# Altium schematic sheet PicoZed.SchDoc
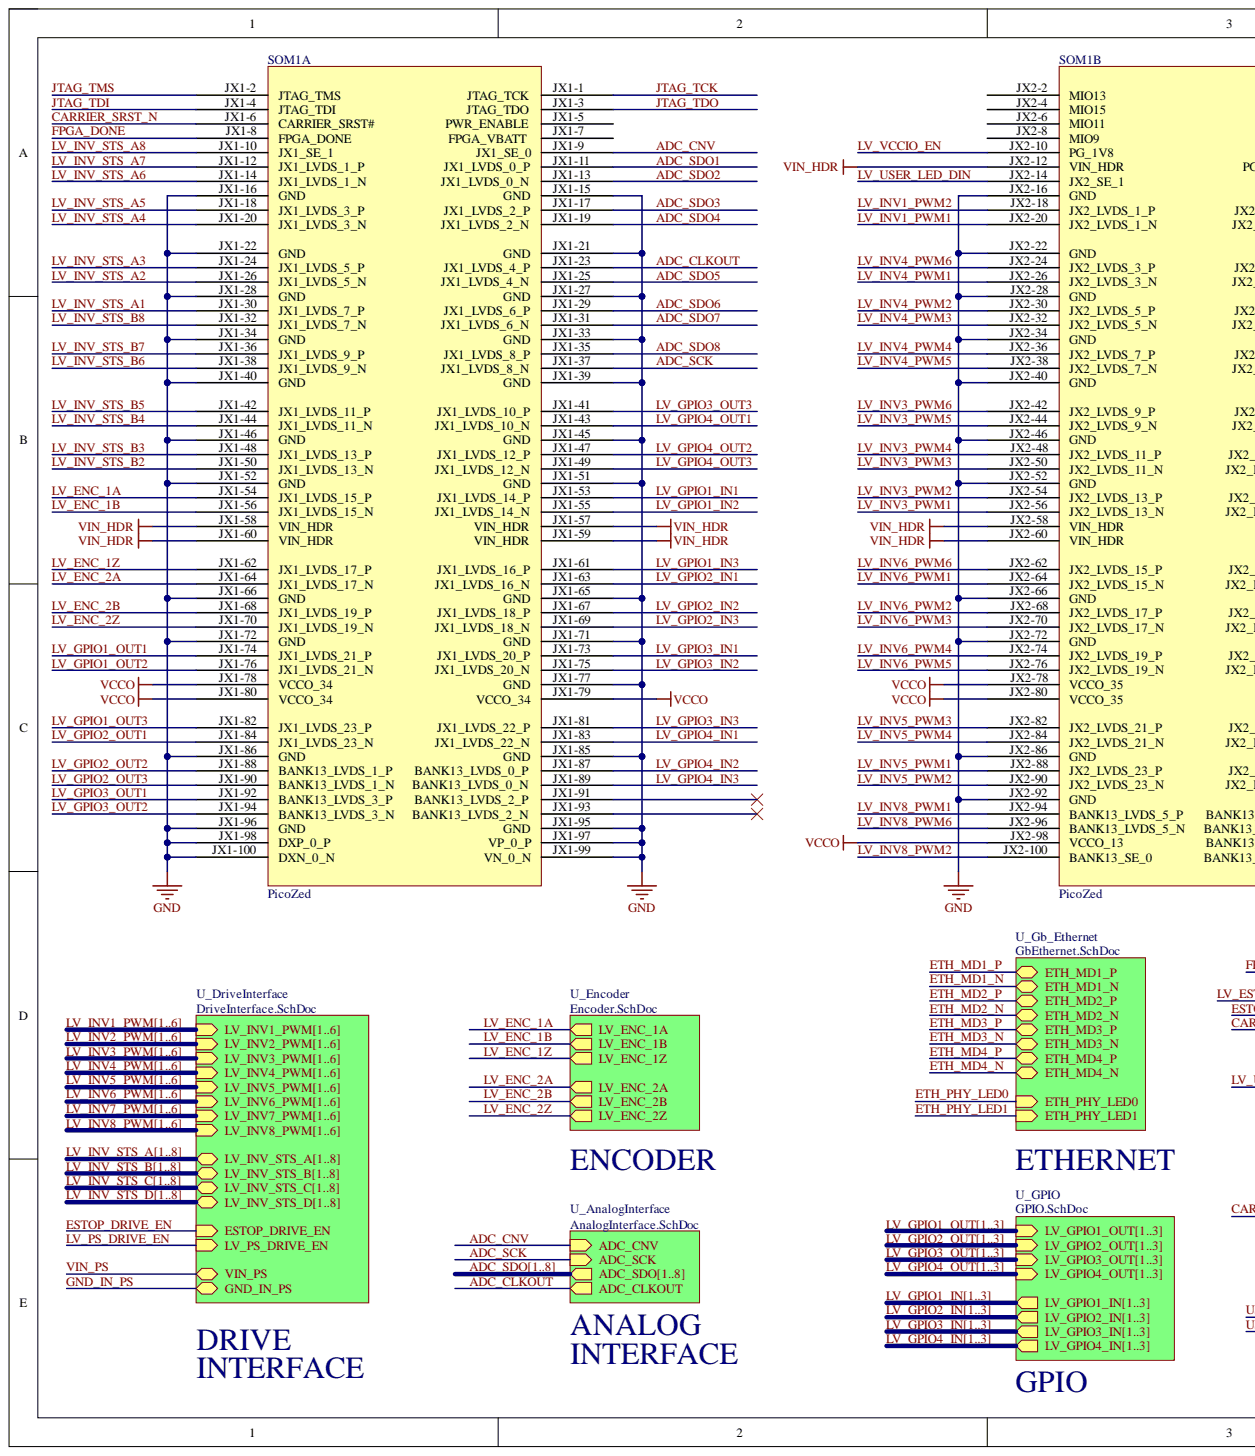
[diagram p0: PicoZed.SchDoc, left]
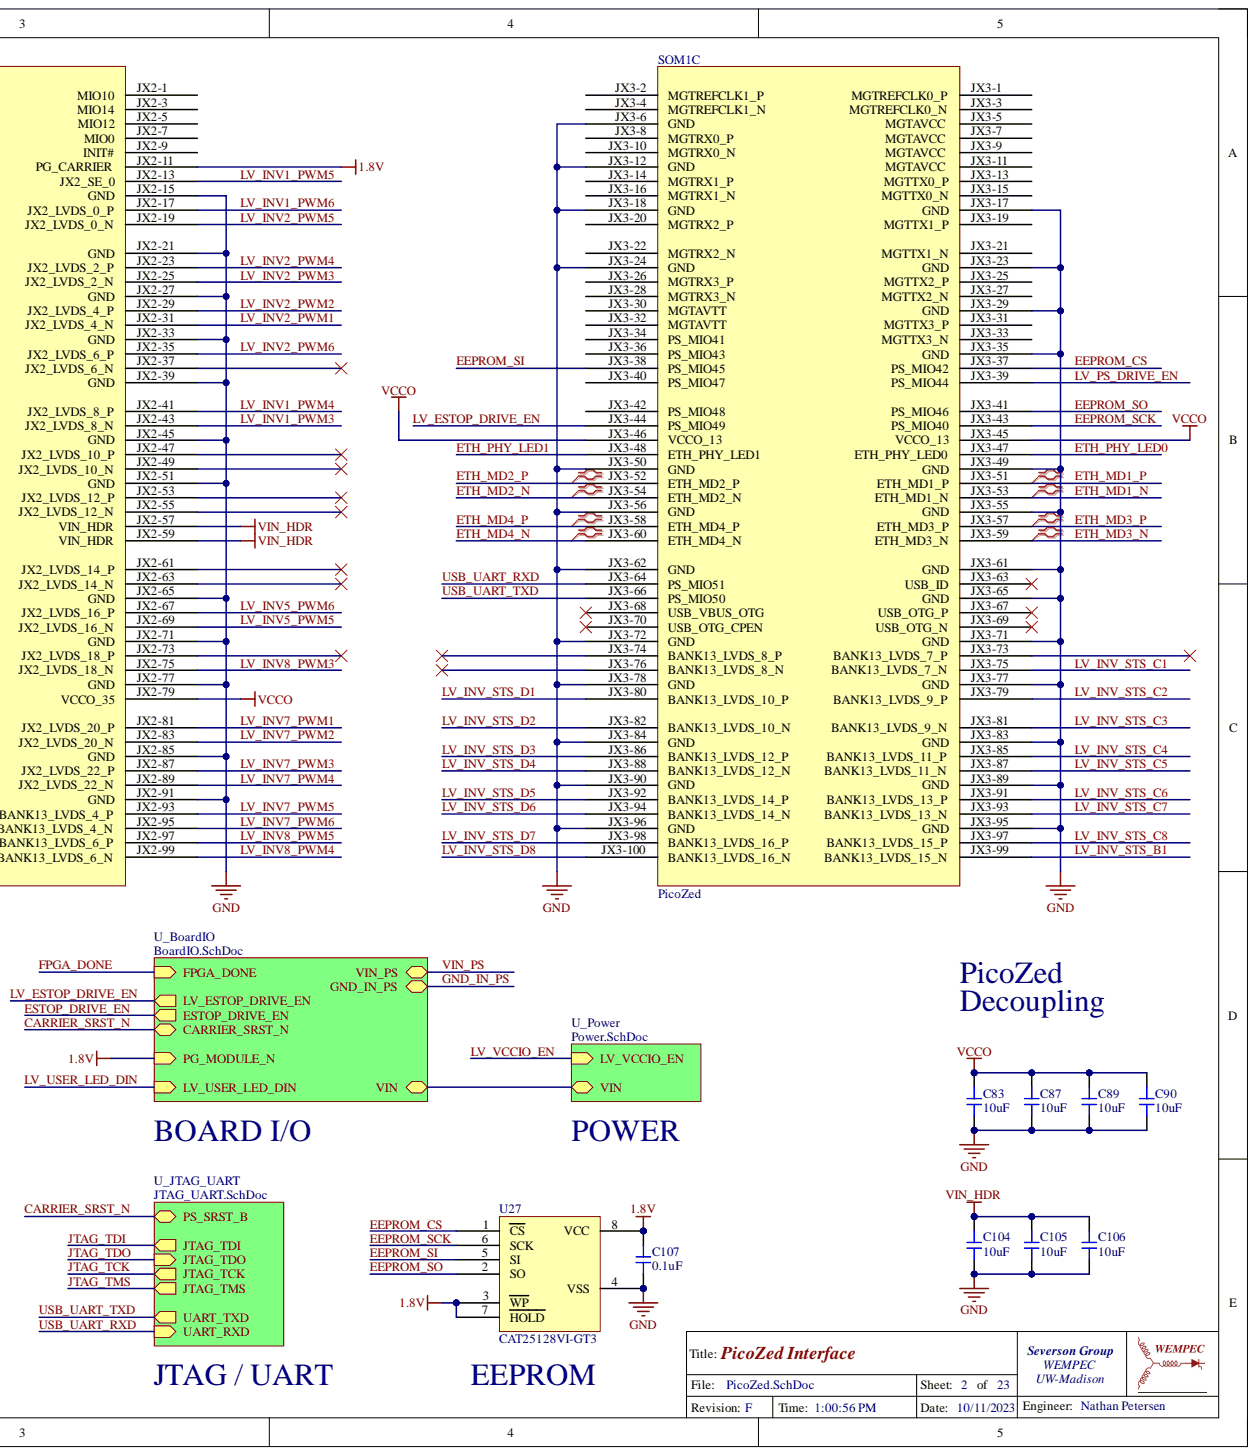
[diagram p1: PicoZed.SchDoc, right]

## Parts
C83  value 10uF  [0603-CAP]  (hidden comment: Capacitor)
C87  value 10uF  [0603-CAP]  (hidden comment: Capacitor)
C89  value 10uF  [0603-CAP]  (hidden comment: Capacitor)
C90  value 10uF  [0603-CAP]  (hidden comment: Capacitor)
C104  value 10uF  [0603-CAP]  (hidden comment: Capacitor)
C105  value 10uF  [0603-CAP]  (hidden comment: Capacitor)
C106  value 10uF  [0603-CAP]  (hidden comment: Capacitor)
C107  value 0.1uF  [0603-CAP]  (hidden comment: Capacitor)
SOM1  PicoZed  [PicoZedSOM]  (no BOM)  (parts A,B,C)
U27  CAT25128VI-GT3  [SOIC127P600X175-8N]

## Nets
1.8V: C107.2 SOM1.JX2-11(PG_CARRIER) U27.3(WP) U27.7(HOLD) U27.8(VCC)
GND: C83.1 C87.1 C89.1 C90.1 C104.1 C105.1 C106.1 C107.1 SOM1.JX1-15(GND) SOM1.JX1-16(GND) SOM1.JX1-21(GND) SOM1.JX1-22(GND) SOM1.JX1-27(GND) SOM1.JX1-28(GND) SOM1.JX1-33(GND) SOM1.JX1-34(GND) SOM1.JX1-39(GND) SOM1.JX1-40(GND) SOM1.JX1-45(GND) SOM1.JX1-46(GND) SOM1.JX1-51(GND) SOM1.JX1-52(GND) SOM1.JX1-65(GND) SOM1.JX1-66(GND) SOM1.JX1-71(GND) SOM1.JX1-72(GND) SOM1.JX1-77(GND) SOM1.JX1-85(GND) SOM1.JX1-86(GND) SOM1.JX1-95(GND) SOM1.JX1-96(GND) SOM1.JX1-97(VP_0_P) SOM1.JX1-98(DXP_0_P) SOM1.JX1-99(VN_0_N) SOM1.JX1-100(DXN_0_N) SOM1.JX2-15(GND) SOM1.JX2-16(GND) SOM1.JX2-21(GND) SOM1.JX2-22(GND) SOM1.JX2-27(GND) SOM1.JX2-28(GND) SOM1.JX2-33(GND) SOM1.JX2-34(GND) SOM1.JX2-39(GND) SOM1.JX2-40(GND) SOM1.JX2-45(GND) SOM1.JX2-46(GND) SOM1.JX2-51(GND) SOM1.JX2-52(GND) SOM1.JX2-65(GND) SOM1.JX2-66(GND) SOM1.JX2-71(GND) SOM1.JX2-72(GND) SOM1.JX2-77(GND) SOM1.JX2-85(GND) SOM1.JX2-86(GND) SOM1.JX2-91(GND) SOM1.JX2-92(GND) SOM1.JX3-6(GND) SOM1.JX3-12(GND) SOM1.JX3-17(GND) SOM1.JX3-18(GND) SOM1.JX3-23(GND) SOM1.JX3-24(GND) SOM1.JX3-29(GND) SOM1.JX3-35(GND) SOM1.JX3-49(GND) SOM1.JX3-50(GND) SOM1.JX3-55(GND) SOM1.JX3-56(GND) SOM1.JX3-61(GND) SOM1.JX3-62(GND) SOM1.JX3-65(GND) SOM1.JX3-71(GND) SOM1.JX3-72(GND) SOM1.JX3-77(GND) SOM1.JX3-78(GND) SOM1.JX3-83(GND) SOM1.JX3-84(GND) SOM1.JX3-89(GND) SOM1.JX3-90(GND) SOM1.JX3-95(GND) SOM1.JX3-96(GND) U27.4(VSS)
VCCO: C83.2 C87.2 C89.2 C90.2 SOM1.JX1-78(VCCO_34) SOM1.JX1-79(VCCO_34) SOM1.JX1-80(VCCO_34) SOM1.JX2-78(VCCO_35) SOM1.JX2-79(VCCO_35) SOM1.JX2-80(VCCO_35) SOM1.JX2-98(VCCO_13) SOM1.JX3-45(VCCO_13) SOM1.JX3-46(VCCO_13)
VIN_HDR: C104.2 C105.2 C106.2 SOM1.JX1-57(VIN_HDR) SOM1.JX1-58(VIN_HDR) SOM1.JX1-59(VIN_HDR) SOM1.JX1-60(VIN_HDR) SOM1.JX2-12(VIN_HDR) SOM1.JX2-57(VIN_HDR) SOM1.JX2-58(VIN_HDR) SOM1.JX2-59(VIN_HDR) SOM1.JX2-60(VIN_HDR)
ADC_CLKOUT: SOM1.JX1-23(JX1_LVDS_4_P)
ADC_CNV: SOM1.JX1-9(JX1_SE_0)
ADC_SCK: SOM1.JX1-37(JX1_LVDS_8_N)
ADC_SDO1: SOM1.JX1-11(JX1_LVDS_0_P)  [also labelled ADC_SDO]
ADC_SDO2: SOM1.JX1-13(JX1_LVDS_0_N)  [also labelled ADC_SDO]
ADC_SDO3: SOM1.JX1-17(JX1_LVDS_2_P)  [also labelled ADC_SDO]
ADC_SDO4: SOM1.JX1-19(JX1_LVDS_2_N)  [also labelled ADC_SDO]
ADC_SDO5: SOM1.JX1-25(JX1_LVDS_4_N)  [also labelled ADC_SDO]
ADC_SDO6: SOM1.JX1-29(JX1_LVDS_6_P)  [also labelled ADC_SDO]
ADC_SDO7: SOM1.JX1-31(JX1_LVDS_6_N)  [also labelled ADC_SDO]
ADC_SDO8: SOM1.JX1-35(JX1_LVDS_8_P)  [also labelled ADC_SDO]
CARRIER_SRST_N: SOM1.JX1-6(CARRIER_SRST#)
EEPROM_CS: SOM1.JX3-37(PS_MIO42) U27.1(CS)
EEPROM_SCK: SOM1.JX3-43(PS_MIO40) U27.6(SCK)
EEPROM_SI: SOM1.JX3-38(PS_MIO45) U27.5(SI)
EEPROM_SO: SOM1.JX3-41(PS_MIO46) U27.2(SO)
ETH_MD1_N: SOM1.JX3-53(ETH_MD1_N)
ETH_MD1_P: SOM1.JX3-51(ETH_MD1_P)
ETH_MD2_N: SOM1.JX3-54(ETH_MD2_N)
ETH_MD2_P: SOM1.JX3-52(ETH_MD2_P)
ETH_MD3_N: SOM1.JX3-59(ETH_MD3_N)
ETH_MD3_P: SOM1.JX3-57(ETH_MD3_P)
ETH_MD4_N: SOM1.JX3-60(ETH_MD4_N)
ETH_MD4_P: SOM1.JX3-58(ETH_MD4_P)
ETH_PHY_LED0: SOM1.JX3-47(ETH_PHY_LED0)
ETH_PHY_LED1: SOM1.JX3-48(ETH_PHY_LED1)
FPGA_DONE: SOM1.JX1-8(FPGA_DONE)
JTAG_TCK: SOM1.JX1-1(JTAG_TCK)
JTAG_TDI: SOM1.JX1-4(JTAG_TDI)
JTAG_TDO: SOM1.JX1-3(JTAG_TDO)
JTAG_TMS: SOM1.JX1-2(JTAG_TMS)
LV_ENC_1A: SOM1.JX1-54(JX1_LVDS_15_P)
LV_ENC_1B: SOM1.JX1-56(JX1_LVDS_15_N)
LV_ENC_1Z: SOM1.JX1-62(JX1_LVDS_17_P)
LV_ENC_2A: SOM1.JX1-64(JX1_LVDS_17_N)
LV_ENC_2B: SOM1.JX1-68(JX1_LVDS_19_P)
LV_ENC_2Z: SOM1.JX1-70(JX1_LVDS_19_N)
LV_ESTOP_DRIVE_EN: SOM1.JX3-44(PS_MIO49)
LV_GPIO1_IN1: SOM1.JX1-53(JX1_LVDS_14_P)  [also labelled LV_GPIO1_IN]
LV_GPIO1_IN2: SOM1.JX1-55(JX1_LVDS_14_N)  [also labelled LV_GPIO1_IN]
LV_GPIO1_IN3: SOM1.JX1-61(JX1_LVDS_16_P)  [also labelled LV_GPIO1_IN]
LV_GPIO1_OUT1: SOM1.JX1-74(JX1_LVDS_21_P)  [also labelled LV_GPIO1_OUT]
LV_GPIO1_OUT2: SOM1.JX1-76(JX1_LVDS_21_N)  [also labelled LV_GPIO1_OUT]
LV_GPIO1_OUT3: SOM1.JX1-82(JX1_LVDS_23_P)  [also labelled LV_GPIO1_OUT]
LV_GPIO2_IN1: SOM1.JX1-63(JX1_LVDS_16_N)  [also labelled LV_GPIO2_IN]
LV_GPIO2_IN2: SOM1.JX1-67(JX1_LVDS_18_P)  [also labelled LV_GPIO2_IN]
LV_GPIO2_IN3: SOM1.JX1-69(JX1_LVDS_18_N)  [also labelled LV_GPIO2_IN]
LV_GPIO2_OUT1: SOM1.JX1-84(JX1_LVDS_23_N)  [also labelled LV_GPIO2_OUT]
LV_GPIO2_OUT2: SOM1.JX1-88(BANK13_LVDS_1_P)  [also labelled LV_GPIO2_OUT]
LV_GPIO2_OUT3: SOM1.JX1-90(BANK13_LVDS_1_N)  [also labelled LV_GPIO2_OUT]
LV_GPIO3_IN1: SOM1.JX1-73(JX1_LVDS_20_P)  [also labelled LV_GPIO3_IN]
LV_GPIO3_IN2: SOM1.JX1-75(JX1_LVDS_20_N)  [also labelled LV_GPIO3_IN]
LV_GPIO3_IN3: SOM1.JX1-81(JX1_LVDS_22_P)  [also labelled LV_GPIO3_IN]
LV_GPIO3_OUT1: SOM1.JX1-92(BANK13_LVDS_3_P)  [also labelled LV_GPIO3_OUT]
LV_GPIO3_OUT2: SOM1.JX1-94(BANK13_LVDS_3_N)  [also labelled LV_GPIO3_OUT]
LV_GPIO3_OUT3: SOM1.JX1-41(JX1_LVDS_10_P)  [also labelled LV_GPIO3_OUT]
LV_GPIO4_IN1: SOM1.JX1-83(JX1_LVDS_22_N)  [also labelled LV_GPIO4_IN]
LV_GPIO4_IN2: SOM1.JX1-87(BANK13_LVDS_0_P)  [also labelled LV_GPIO4_IN]
LV_GPIO4_IN3: SOM1.JX1-89(BANK13_LVDS_0_N)  [also labelled LV_GPIO4_IN]
LV_GPIO4_OUT1: SOM1.JX1-43(JX1_LVDS_10_N)  [also labelled LV_GPIO4_OUT]
LV_GPIO4_OUT2: SOM1.JX1-47(JX1_LVDS_12_P)  [also labelled LV_GPIO4_OUT]
LV_GPIO4_OUT3: SOM1.JX1-49(JX1_LVDS_12_N)  [also labelled LV_GPIO4_OUT]
LV_INV1_PWM1: SOM1.JX2-20(JX2_LVDS_1_N)  [also labelled LV_INV1_PWM]
LV_INV1_PWM2: SOM1.JX2-18(JX2_LVDS_1_P)  [also labelled LV_INV1_PWM]
LV_INV1_PWM3: SOM1.JX2-43(JX2_LVDS_8_N)  [also labelled LV_INV1_PWM]
LV_INV1_PWM4: SOM1.JX2-41(JX2_LVDS_8_P)  [also labelled LV_INV1_PWM]
LV_INV1_PWM5: SOM1.JX2-13(JX2_SE_0)  [also labelled LV_INV1_PWM]
LV_INV1_PWM6: SOM1.JX2-17(JX2_LVDS_0_P)  [also labelled LV_INV1_PWM]
LV_INV2_PWM1: SOM1.JX2-31(JX2_LVDS_4_N)  [also labelled LV_INV2_PWM]
LV_INV2_PWM2: SOM1.JX2-29(JX2_LVDS_4_P)  [also labelled LV_INV2_PWM]
LV_INV2_PWM3: SOM1.JX2-25(JX2_LVDS_2_N)  [also labelled LV_INV2_PWM]
LV_INV2_PWM4: SOM1.JX2-23(JX2_LVDS_2_P)  [also labelled LV_INV2_PWM]
LV_INV2_PWM5: SOM1.JX2-19(JX2_LVDS_0_N)  [also labelled LV_INV2_PWM]
LV_INV2_PWM6: SOM1.JX2-35(JX2_LVDS_6_P)  [also labelled LV_INV2_PWM]
LV_INV3_PWM1: SOM1.JX2-56(JX2_LVDS_13_N)  [also labelled LV_INV3_PWM]
LV_INV3_PWM2: SOM1.JX2-54(JX2_LVDS_13_P)  [also labelled LV_INV3_PWM]
LV_INV3_PWM3: SOM1.JX2-50(JX2_LVDS_11_N)  [also labelled LV_INV3_PWM]
LV_INV3_PWM4: SOM1.JX2-48(JX2_LVDS_11_P)  [also labelled LV_INV3_PWM]
LV_INV3_PWM5: SOM1.JX2-44(JX2_LVDS_9_N)  [also labelled LV_INV3_PWM]
LV_INV3_PWM6: SOM1.JX2-42(JX2_LVDS_9_P)  [also labelled LV_INV3_PWM]
LV_INV4_PWM1: SOM1.JX2-26(JX2_LVDS_3_N)  [also labelled LV_INV4_PWM]
LV_INV4_PWM2: SOM1.JX2-30(JX2_LVDS_5_P)  [also labelled LV_INV4_PWM]
LV_INV4_PWM3: SOM1.JX2-32(JX2_LVDS_5_N)  [also labelled LV_INV4_PWM]
LV_INV4_PWM4: SOM1.JX2-36(JX2_LVDS_7_P)  [also labelled LV_INV4_PWM]
LV_INV4_PWM5: SOM1.JX2-38(JX2_LVDS_7_N)  [also labelled LV_INV4_PWM]
LV_INV4_PWM6: SOM1.JX2-24(JX2_LVDS_3_P)  [also labelled LV_INV4_PWM]
LV_INV5_PWM1: SOM1.JX2-88(JX2_LVDS_23_P)  [also labelled LV_INV5_PWM]
LV_INV5_PWM2: SOM1.JX2-90(JX2_LVDS_23_N)  [also labelled LV_INV5_PWM]
LV_INV5_PWM3: SOM1.JX2-82(JX2_LVDS_21_P)  [also labelled LV_INV5_PWM]
LV_INV5_PWM4: SOM1.JX2-84(JX2_LVDS_21_N)  [also labelled LV_INV5_PWM]
LV_INV5_PWM5: SOM1.JX2-69(JX2_LVDS_16_N)  [also labelled LV_INV5_PWM]
LV_INV5_PWM6: SOM1.JX2-67(JX2_LVDS_16_P)  [also labelled LV_INV5_PWM]
LV_INV6_PWM1: SOM1.JX2-64(JX2_LVDS_15_N)  [also labelled LV_INV6_PWM]
LV_INV6_PWM2: SOM1.JX2-68(JX2_LVDS_17_P)  [also labelled LV_INV6_PWM]
LV_INV6_PWM3: SOM1.JX2-70(JX2_LVDS_17_N)  [also labelled LV_INV6_PWM]
LV_INV6_PWM4: SOM1.JX2-74(JX2_LVDS_19_P)  [also labelled LV_INV6_PWM]
LV_INV6_PWM5: SOM1.JX2-76(JX2_LVDS_19_N)  [also labelled LV_INV6_PWM]
LV_INV6_PWM6: SOM1.JX2-62(JX2_LVDS_15_P)  [also labelled LV_INV6_PWM]
LV_INV7_PWM1: SOM1.JX2-81(JX2_LVDS_20_P)  [also labelled LV_INV7_PWM]
LV_INV7_PWM2: SOM1.JX2-83(JX2_LVDS_20_N)  [also labelled LV_INV7_PWM]
LV_INV7_PWM3: SOM1.JX2-87(JX2_LVDS_22_P)  [also labelled LV_INV7_PWM]
LV_INV7_PWM4: SOM1.JX2-89(JX2_LVDS_22_N)  [also labelled LV_INV7_PWM]
LV_INV7_PWM5: SOM1.JX2-93(BANK13_LVDS_4_P)  [also labelled LV_INV7_PWM]
LV_INV7_PWM6: SOM1.JX2-95(BANK13_LVDS_4_N)  [also labelled LV_INV7_PWM]
LV_INV8_PWM1: SOM1.JX2-94(BANK13_LVDS_5_P)  [also labelled LV_INV8_PWM]
LV_INV8_PWM2: SOM1.JX2-100(BANK13_SE_0)  [also labelled LV_INV8_PWM]
LV_INV8_PWM3: SOM1.JX2-75(JX2_LVDS_18_N)  [also labelled LV_INV8_PWM]
LV_INV8_PWM4: SOM1.JX2-99(BANK13_LVDS_6_N)  [also labelled LV_INV8_PWM]
LV_INV8_PWM5: SOM1.JX2-97(BANK13_LVDS_6_P)  [also labelled LV_INV8_PWM]
LV_INV8_PWM6: SOM1.JX2-96(BANK13_LVDS_5_N)  [also labelled LV_INV8_PWM]
LV_INV_STS_A1: SOM1.JX1-30(JX1_LVDS_7_P)  [also labelled LV_INV_STS_A]
LV_INV_STS_A2: SOM1.JX1-26(JX1_LVDS_5_N)  [also labelled LV_INV_STS_A]
LV_INV_STS_A3: SOM1.JX1-24(JX1_LVDS_5_P)  [also labelled LV_INV_STS_A]
LV_INV_STS_A4: SOM1.JX1-20(JX1_LVDS_3_N)  [also labelled LV_INV_STS_A]
LV_INV_STS_A5: SOM1.JX1-18(JX1_LVDS_3_P)  [also labelled LV_INV_STS_A]
LV_INV_STS_A6: SOM1.JX1-14(JX1_LVDS_1_N)  [also labelled LV_INV_STS_A]
LV_INV_STS_A7: SOM1.JX1-12(JX1_LVDS_1_P)  [also labelled LV_INV_STS_A]
LV_INV_STS_A8: SOM1.JX1-10(JX1_SE_1)  [also labelled LV_INV_STS_A]
LV_INV_STS_B1: SOM1.JX3-99(BANK13_LVDS_15_N)  [also labelled LV_INV_STS_B]
LV_INV_STS_B2: SOM1.JX1-50(JX1_LVDS_13_N)  [also labelled LV_INV_STS_B]
LV_INV_STS_B3: SOM1.JX1-48(JX1_LVDS_13_P)  [also labelled LV_INV_STS_B]
LV_INV_STS_B4: SOM1.JX1-44(JX1_LVDS_11_N)  [also labelled LV_INV_STS_B]
LV_INV_STS_B5: SOM1.JX1-42(JX1_LVDS_11_P)  [also labelled LV_INV_STS_B]
LV_INV_STS_B6: SOM1.JX1-38(JX1_LVDS_9_N)  [also labelled LV_INV_STS_B]
LV_INV_STS_B7: SOM1.JX1-36(JX1_LVDS_9_P)  [also labelled LV_INV_STS_B]
LV_INV_STS_B8: SOM1.JX1-32(JX1_LVDS_7_N)  [also labelled LV_INV_STS_B]
LV_INV_STS_C1: SOM1.JX3-75(BANK13_LVDS_7_N)  [also labelled LV_INV_STS_C]
LV_INV_STS_C2: SOM1.JX3-79(BANK13_LVDS_9_P)  [also labelled LV_INV_STS_C]
LV_INV_STS_C3: SOM1.JX3-81(BANK13_LVDS_9_N)  [also labelled LV_INV_STS_C]
LV_INV_STS_C4: SOM1.JX3-85(BANK13_LVDS_11_P)  [also labelled LV_INV_STS_C]
LV_INV_STS_C5: SOM1.JX3-87(BANK13_LVDS_11_N)  [also labelled LV_INV_STS_C]
LV_INV_STS_C6: SOM1.JX3-91(BANK13_LVDS_13_P)  [also labelled LV_INV_STS_C]
LV_INV_STS_C7: SOM1.JX3-93(BANK13_LVDS_13_N)  [also labelled LV_INV_STS_C]
LV_INV_STS_C8: SOM1.JX3-97(BANK13_LVDS_15_P)  [also labelled LV_INV_STS_C]
LV_INV_STS_D1: SOM1.JX3-80(BANK13_LVDS_10_P)  [also labelled LV_INV_STS_D]
LV_INV_STS_D2: SOM1.JX3-82(BANK13_LVDS_10_N)  [also labelled LV_INV_STS_D]
LV_INV_STS_D3: SOM1.JX3-86(BANK13_LVDS_12_P)  [also labelled LV_INV_STS_D]
LV_INV_STS_D4: SOM1.JX3-88(BANK13_LVDS_12_N)  [also labelled LV_INV_STS_D]
LV_INV_STS_D5: SOM1.JX3-92(BANK13_LVDS_14_P)  [also labelled LV_INV_STS_D]
LV_INV_STS_D6: SOM1.JX3-94(BANK13_LVDS_14_N)  [also labelled LV_INV_STS_D]
LV_INV_STS_D7: SOM1.JX3-98(BANK13_LVDS_16_P)  [also labelled LV_INV_STS_D]
LV_INV_STS_D8: SOM1.JX3-100(BANK13_LVDS_16_N)  [also labelled LV_INV_STS_D]
LV_PS_DRIVE_EN: SOM1.JX3-39(PS_MIO44)
LV_USER_LED_DIN: SOM1.JX2-14(JX2_SE_1)
LV_VCCIO_EN: SOM1.JX2-10(PG_1V8)
USB_UART_RXD: SOM1.JX3-64(PS_MIO51)
USB_UART_TXD: SOM1.JX3-66(PS_MIO50)
NetSOM1_JX1-5: SOM1.JX1-5(PWR_ENABLE)
NetSOM1_JX1-7: SOM1.JX1-7(FPGA_VBATT)
NetSOM1_JX1-91: SOM1.JX1-91(BANK13_LVDS_2_P)
NetSOM1_JX1-93: SOM1.JX1-93(BANK13_LVDS_2_N)
NetSOM1_JX2-1: SOM1.JX2-1(MIO10)
NetSOM1_JX2-2: SOM1.JX2-2(MIO13)
NetSOM1_JX2-3: SOM1.JX2-3(MIO14)
NetSOM1_JX2-4: SOM1.JX2-4(MIO15)
NetSOM1_JX2-5: SOM1.JX2-5(MIO12)
NetSOM1_JX2-6: SOM1.JX2-6(MIO11)
NetSOM1_JX2-7: SOM1.JX2-7(MIO0)
NetSOM1_JX2-8: SOM1.JX2-8(MIO9)
NetSOM1_JX2-9: SOM1.JX2-9(INIT#)
NetSOM1_JX2-37: SOM1.JX2-37(JX2_LVDS_6_N)
NetSOM1_JX2-47: SOM1.JX2-47(JX2_LVDS_10_P)
NetSOM1_JX2-49: SOM1.JX2-49(JX2_LVDS_10_N)
NetSOM1_JX2-53: SOM1.JX2-53(JX2_LVDS_12_P)
NetSOM1_JX2-55: SOM1.JX2-55(JX2_LVDS_12_N)
NetSOM1_JX2-61: SOM1.JX2-61(JX2_LVDS_14_P)
NetSOM1_JX2-63: SOM1.JX2-63(JX2_LVDS_14_N)
NetSOM1_JX2-73: SOM1.JX2-73(JX2_LVDS_18_P)
NetSOM1_JX3-1: SOM1.JX3-1(MGTREFCLK0_P)
NetSOM1_JX3-2: SOM1.JX3-2(MGTREFCLK1_P)
NetSOM1_JX3-3: SOM1.JX3-3(MGTREFCLK0_N)
NetSOM1_JX3-4: SOM1.JX3-4(MGTREFCLK1_N)
NetSOM1_JX3-5: SOM1.JX3-5(MGTAVCC)
NetSOM1_JX3-7: SOM1.JX3-7(MGTAVCC)
NetSOM1_JX3-8: SOM1.JX3-8(MGTRX0_P)
NetSOM1_JX3-9: SOM1.JX3-9(MGTAVCC)
NetSOM1_JX3-10: SOM1.JX3-10(MGTRX0_N)
NetSOM1_JX3-11: SOM1.JX3-11(MGTAVCC)
NetSOM1_JX3-13: SOM1.JX3-13(MGTTX0_P)
NetSOM1_JX3-14: SOM1.JX3-14(MGTRX1_P)
NetSOM1_JX3-15: SOM1.JX3-15(MGTTX0_N)
NetSOM1_JX3-16: SOM1.JX3-16(MGTRX1_N)
NetSOM1_JX3-19: SOM1.JX3-19(MGTTX1_P)
NetSOM1_JX3-20: SOM1.JX3-20(MGTRX2_P)
NetSOM1_JX3-21: SOM1.JX3-21(MGTTX1_N)
NetSOM1_JX3-22: SOM1.JX3-22(MGTRX2_N)
NetSOM1_JX3-25: SOM1.JX3-25(MGTTX2_P)
NetSOM1_JX3-26: SOM1.JX3-26(MGTRX3_P)
NetSOM1_JX3-27: SOM1.JX3-27(MGTTX2_N)
NetSOM1_JX3-28: SOM1.JX3-28(MGTRX3_N)
NetSOM1_JX3-30: SOM1.JX3-30(MGTAVTT)
NetSOM1_JX3-31: SOM1.JX3-31(MGTTX3_P)
NetSOM1_JX3-32: SOM1.JX3-32(MGTAVTT)
NetSOM1_JX3-33: SOM1.JX3-33(MGTTX3_N)
NetSOM1_JX3-34: SOM1.JX3-34(PS_MIO41)
NetSOM1_JX3-36: SOM1.JX3-36(PS_MIO43)
NetSOM1_JX3-40: SOM1.JX3-40(PS_MIO47)
NetSOM1_JX3-42: SOM1.JX3-42(PS_MIO48)
NetSOM1_JX3-63: SOM1.JX3-63(USB_ID)
NetSOM1_JX3-67: SOM1.JX3-67(USB_OTG_P)
NetSOM1_JX3-68: SOM1.JX3-68(USB_VBUS_OTG)
NetSOM1_JX3-69: SOM1.JX3-69(USB_OTG_N)
NetSOM1_JX3-70: SOM1.JX3-70(USB_OTG_CPEN)
NetSOM1_JX3-73: SOM1.JX3-73(BANK13_LVDS_7_P)
NetSOM1_JX3-74: SOM1.JX3-74(BANK13_LVDS_8_P)
NetSOM1_JX3-76: SOM1.JX3-76(BANK13_LVDS_8_N)
ESTOP_DRIVE_EN:
GND_IN_PS:
N00001:
VIN_PS:

## Library data of the parts
SOM1 = PicoZed_SOM: PicoZed SOM Carrier Footprint; Library Name: PicoZedSchLib.SchLib
U27 = CAT25128VI-GT3: IC EEPROM 128K SPI 20MHZ 8SOIC; Component Kind: Standard; Supplier 1: Digi-Key; Supplier Part Number 1: CAT25128VI-GT3OSCT-ND; Library Name: AMDC.SchLib
C83 C87 C89 C90 C104-C107 = Capacitor: Component Kind: Standard; Description: Capacitor; Simulation: CAP; Supplier 1: Digi-Key; Library Name: AMDC.SchLib
  C83 C87 C89 C90 C104-C106: Supplier Part Number 1: 1276-1119-6-ND
  C107: Supplier Part Number 1: 1276-1012-6-ND
